# Altium schematic sheet CW562_DriverBoard_MotorDriver.SchDoc
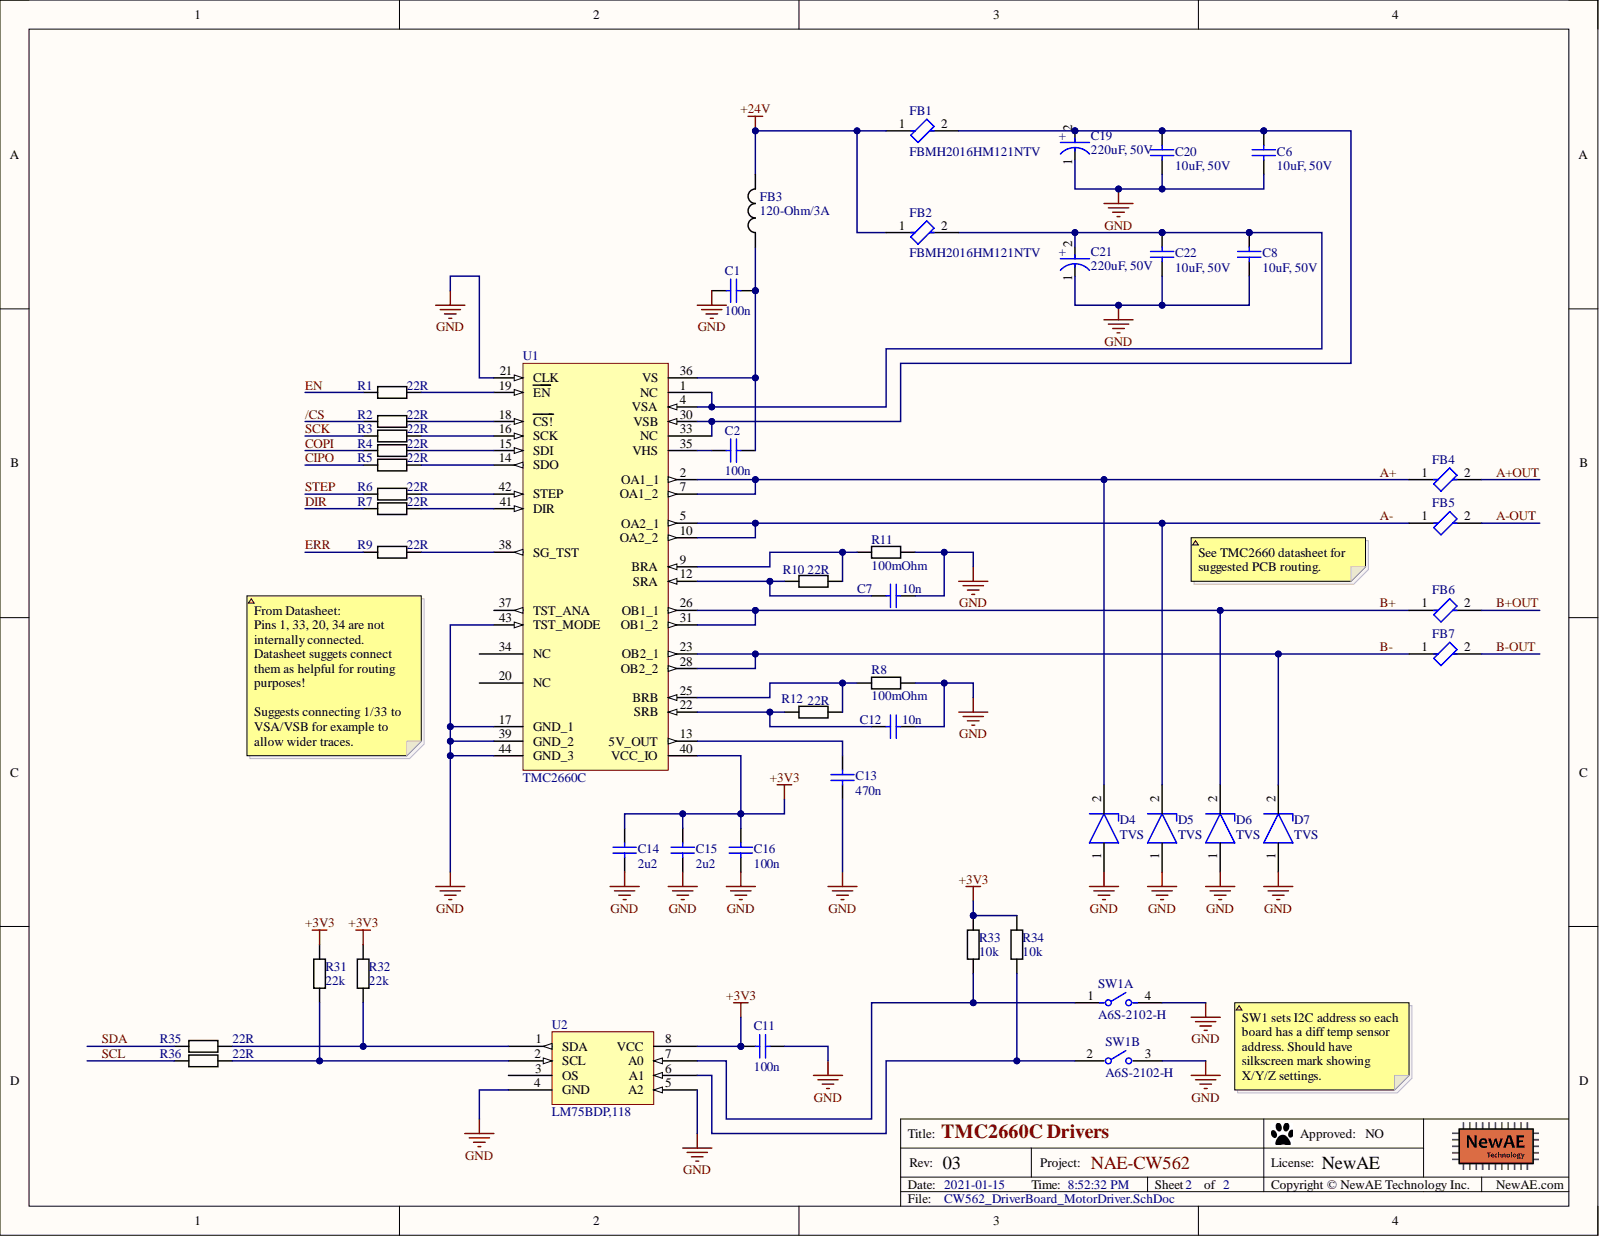
[diagram p0: CW562_DriverBoard_MotorDriver.SchDoc]

## Parts
C1  100n  [0603]
C2  100n  [0603]
C6  10uF, 50V  Voltage Rating DC: 50V  [MURA-1206_H_1.8_M]
C7  10n  [0603]
C8  10uF, 50V  Voltage Rating DC: 50V  [MURA-1206_H_1.8_M]
C11  100n  [0603]
C12  10n  [0603]
C13  470n  [0603]
C14  2u2  [0603]
C15  2u2  [0603]
C16  100n  [0603]
C19  220uF, 50V  [FP-FKG-MFG]
C20  10uF, 50V  Voltage Rating DC: 50V  [MURA-1206_H_1.8_M]
C21  220uF, 50V  [FP-FKG-MFG]
C22  10uF, 50V  Voltage Rating DC: 50V  [MURA-1206_H_1.8_M]
D4  TVS  [FP-S8-V-3915_01-001-MFG]
D5  TVS  [FP-S8-V-3915_01-001-MFG]
D6  TVS  [FP-S8-V-3915_01-001-MFG]
D7  TVS  [FP-S8-V-3915_01-001-MFG]
FB1  FBMH2016HM121NTV  [FP-FBMH2016-IPC_A]
FB2  FBMH2016HM121NTV  [FP-FBMH2016-IPC_A]
FB3  120-Ohm/3A  [0603]
FB4  FBMH2016HM121NTV  [FP-FBMH2016-IPC_A]
FB5  FBMH2016HM121NTV  [FP-FBMH2016-IPC_A]
FB6  FBMH2016HM121NTV  [FP-FBMH2016-IPC_A]
FB7  FBMH2016HM121NTV  [FP-FBMH2016-IPC_A]
R1  22R  [0603]
R2  22R  [0603]
R3  22R  [0603]
R4  22R  [0603]
R5  22R  [0603]
R6  22R  [0603]
R7  22R  [0603]
R8  100mOhm  [1206]
R9  22R  [0603]
R10  22R  [0603]
R11  100mOhm  [1206]
R12  22R  [0603]
R31  22k  [0603]
R32  22k  [0603]
R33  10k  [0603]
R34  10k  [0603]
R35  22R  [0603]
R36  22R  [0603]
SW1  A6S-2102-H  [FP-A6S-2102-H-MFG]  (parts A,B)
U1  TMC2660C  [TQFP44]
U2  LM75BDP,118  [TSSOP8]

## Nets
+3V3: C11.1 C14.1 C15.1 C16.1 R31.1 R32.1 R33.1 R34.1 U1.40(VCC_IO) U2.8(VCC)
+24V: FB1.1 FB2.1 FB3.2
GND: C1.1 C6.1 C7.1 C8.1 C11.2 C12.1 C13.2 C14.2 C15.2 C16.2 C19.1 C20.1 C21.1 C22.1 D4.1 D5.1 D6.1 D7.1 R8.2 R11.2 SW1.3 SW1.4 U1.17(GND_1) U1.21(CLK) U1.39(GND_2) U1.43(TST_MODE) U1.44(GND_3) U2.4(GND) U2.5(A2)
/CS: R2.1
A+: D4.2 FB4.1 U1.2(OA1_1) U1.7(OA1_2)
A+OUT: FB4.2
A-: D5.2 FB5.1 U1.5(OA2_1) U1.10(OA2_2)
A-OUT: FB5.2
B+: D6.2 FB6.1 U1.26(OB1_1) U1.31(OB1_2)
B+OUT: FB6.2
B-: D7.2 FB7.1 U1.23(OB2_1) U1.28(OB2_2)
B-OUT: FB7.2
CIPO: R5.1
COPI: R4.1
DIR: R7.1
EN: R1.1
ERR: R9.1
SCK: R3.1
SCL: R36.1
SDA: R35.1
STEP: R6.1
NetC1_2: C1.2 C2.2 FB3.1 U1.36(VS)
NetC2_1: C2.1 U1.35(VHS)
NetC6_2: C6.2 C19.2 C20.2 FB1.2 U1.30(VSB) U1.33(NC)
NetC7_2: C7.2 R10.1 U1.12(SRA)
NetC8_2: C8.2 C21.2 C22.2 FB2.2 U1.1(NC) U1.4(VSA)
NetC12_2: C12.2 R12.1 U1.22(SRB)
NetC13_1: C13.1 U1.13(5V_OUT)
NetR1_2: R1.2 U1.19(EN)
NetR2_2: R2.2 U1.18(CS!)
NetR3_2: R3.2 U1.16(SCK)
NetR4_2: R4.2 U1.15(SDI)
NetR5_2: R5.2 U1.14(SDO)
NetR6_2: R6.2 U1.42(STEP)
NetR7_2: R7.2 U1.41(DIR)
NetR8_1: R8.1 R12.2 U1.25(BRB)
NetR9_2: R9.2 U1.38(SG_TST)
NetR10_2: R10.2 R11.1 U1.9(BRA)
NetR31_2: R31.2 R36.2 U2.2(SCL)
NetR32_2: R32.2 R35.2 U2.1(SDA)
NetR33_2: R33.2 SW1.1 U2.7(A0)
NetR34_2: R34.2 SW1.2 U2.6(A1)

Not connected: U1 20(NC) 34(NC) 37(TST_ANA); U2 3(OS)

## Library data of the parts
C1 C2 C11 C16 = CCHIP-100n-0603: 100n, 0603; Manufacture 1: Kemet; Manufacture Part Number 1: C0603C104K5RACTU; Case-Metric: 1608; Component Group: Capacitor; Database Table Name: main; Rated Voltage: 50VDC; Case-EIA: 0603; Component Kind: Standard; Component Type: Standard; Library Name: NewAE_AltiumLib.DBLib; Mounting Technology: SMT; Part Number: CCHIP-100n-0603; Pin Count: 2; Supplier 1: Digi-Key; Supplier Part Number 1: 399-5089-2-ND; Value: 100n
C6 C8 C20 C22 = CMP-2000-05256-1: CAP, Ceramic, 10 uF, 10%, 50 V, 1206, RoHS, Tape and Reel; Manufacturer: Murata; Brand: MurataElectronics; Case Height: 1.6mm; Case Length: 3.2mm; Dielectric: X5R; Type: GeneralPurposeMLCC; Capacitance: 10uF; Case Code - in: 1206; Case Code - mm: 3216; Case Width: 1.6mm; Component Kind: Standard; Datasheet URL: http://download.siliconexpert.com/pdfs/2015/11/6/15/23/32/328/mrt_/manual/2905595417290350grm31cr61h106ka1223.pdf; Datasheet Version: Nov-15; Factory Pack Quantity: 2000; Library Name: Altium Content Vault; Manufacturer URL: http://www.murata.com/; Maximum Operating Temperature: +85C; Minimum Operating Temperature: -55C; Package / Case: 1206(3216metric); Packaging: Reel; Product: GeneralTypeMLCCs; Product Category: MultilayerCeramicCapacitorsMLCC-SMD/SMT; RoHS: Details; Series: GRM; Termination Style: SMD/SMT; Tolerance: 10%
C7 C12 = CCHIP-10n-0603: 10n, 0603; Case-Metric: 1608; Component Group: Capacitor; Database Table Name: main; Case-EIA: 0603; Component Kind: Standard; Component Type: Standard; Library Name: NewAE_AltiumLib.DBLib; Mounting Technology: SMT; Part Number: CCHIP-10n-0603; Pin Count: 2; Supplier 1: Digi-Key; Supplier Part Number 1: 399-1091-1-ND; Value: 10n
C13 = CCHIP-470n-0603-50V: 470n, 0603, 50V; Manufacture 1: Taiyo Yuden; Manufacture Part Number 1: UMK107B7474KA-TR; Component Group: Capacitor; Database Table Name: main; Rated Voltage: 50VDC; Case-EIA: 0603; Component Kind: Standard; Component Type: Standard; Library Name: NewAE_AltiumLib.DBLib; Mounting Technology: SMT; Part Number: CCHIP-470n-0603-50V; Pin Count: 2; RoHS: Yes; Supplier 1: Digi-Key; Supplier Part Number 1: 587-3170-1-ND; Temperature Range: -55C to 125C; Value: 470n
C14 C15 = CCHIP-2u2-0603: 2u2, 0603; Manufacture 1: Samsung; Manufacture Part Number 1: CL10B225KP8NNNC; Case-Metric: 1608; Component Group: Capacitor; Database Table Name: main; Rated Voltage: 10VDC; Case-EIA: 0603; Component Kind: Standard; Component Type: Standard; Library Name: NewAE_AltiumLib.DBLib; Mounting Technology: SMT; Part Number: CCHIP-2u2-0603; Pin Count: 2; Supplier 1: Digi-Key; Supplier Part Number 1: 1276-1134-2-ND; Value: 2u2
C19 C21 = CMP-05427-000014-1: CAP ALUM 220UF 20% 50V SMD; Manufacturer: Panasonic; Manufacturer Part Number: EEEFK1H221P; Automotive Grade: Grade 2; Dissipation Factor: 10%; Esr: 0.18Ω; Leakage Current: 110uA; Life: 2000Hours; Material: Aluminum; Ripple Current: 670mA; Automotive: Yes; Capacitance: 220uF; Category: Cap; Component Kind: Standard; ComponentLink2Description: Datasheet; ComponentLink2URL: https://industrial.panasonic.com/cdbs/www-data/pdf/RDE0000/ABA0000C1181.pdf; Device Class L1: Passive Components; Device Class L2: Capacitors; Device Class L3: Aluminum Electrolytic Capacitors; Digikey Description: CAP ALUM 220UF 20% 50V SMD; Height: 10.5mm; Lead Free: Yes; Library Name: Altium Content Vault; Mouser Description: Cap Aluminum Lytic 220uF 50V 20% (10 X 10.2mm) SMD 670mA 2000h 105C Automotive T/R; Octopart: https://octopart.com/eeefk1h221p-panasonic-1332836; Package: FKG; RoHS: TRUE; Temperature: -55°C to +105°C; Temperature Range High: +105°C; Temperature Range Low: -55°C; Tolerance: 20%; Value: 220uF; Voltage Rating: 50V
D4-D7 = CMP-02577-000004-1: TVS DIODE 30V 48.4V SMF; Manufacturer: Vishay; Manufacturer Part Number: SMF30A-E3-08; Breakdown Voltage: 33.3V; Capacitance: 207pF; Direction: Unidirectional; Max Junction Temp: +175°C; Number Of Channels: 1; Peak Pulse Current: 4.1A; Peak Pulse Power: 200W; Standoff Height: 0mm; Value: 207pF; Automotive: No; Category: Diode; Clamping Voltage: 48.4V; Component Kind: Standard; ComponentLink2Description: Datasheet; ComponentLink2URL: https://www.vishay.com/docs/85881/smf5v0atosmf58a.pdf; Device Class L1: Circuit Protection; Device Class L2: TVS Diodes; Device Class L3: unset; Digikey Description: TVS DIODE 30V 48.4V SMF; Height: 1.08mm; Lead Free: Yes; Library Name: Altium Content Vault; Mouser Description: ESD Suppressors / TVS Diodes 1000watt 30volt; Octopart: https://octopart.com/smf30a-e3-08-vishay-33599955; Package: S8-V-3915.01-001; Reverse Standoff Voltage: 30V; RoHS: TRUE; Temperature: -65°C to +175°C; Temperature Range High: +175°C; Temperature Range Low: -65°C
FB1 FB2 FB4-FB7 = CMP-14488-000003-1: FERRITE BEAD 120 OHM 0806 1LN; Manufacturer: Taiyo Yuden; Automotive Grade: Grade 1; Impedance At Frequency: 120Ω @ 100MHz; Rms Current: 4.5A; Series Resistance: 15mΩ; Test Frequency: 100MHz; Tolerance: 30%; Automotive: Yes; Category: FBead; Component Kind: Standard; ComponentLink2Description: Datasheet; ComponentLink2URL: https://drive.google.com/open?id=1UqnfixXgvx5IH7PdCJ4hyip2nSOHHYWH; Core Material: Ferrite; Device Class L1: Passive Components; Device Class L2: EMI / RFI Components; Device Class L3: Ferrite Beads and Chips; Digikey Description: FERRITE BEAD 120 OHM 0806 1LN; Height: 1.8mm; Ipc Land Pattern Name: CAPC200160X160; Lead Free: Yes; Library Name: Altium Content Vault; Manufacturer Part Number: FBMH2016HM121NTV; Mouser Description: Ferrite Beads HI CUR CHIP BD 0806 AEC-Q200 120 Ohm 30%; Octopart: https://octopart.com/fbmh2016hm121ntv-taiyo+yuden-46994035; Package: FBMH2016; RoHS: TRUE; Temperature: -40°C to +125°C; Temperature Range High: +125°C; Temperature Range Low: -40°C
FB3 = FBCHIP-120R-0603: 120 Ohm @ 100 MHz, 3A rated FB; Manufacture 1: Murata Electronics; Manufacture Part Number 1: BLM18SG121TN1D; Case-Metric: 1680; Component Group: Inductor; Database Table Name: main; PP Material Stack: 0; Value: 120R/3A; Case-EIA: 0603; Component Kind: Standard; Component Type: Standard; Library Name: NewAE_AltiumLib.DBLib; Part Number: FBCHIP-120R-0603; Pin Count: 2; PP Rotation: 0; Rated Power: 3A; Supplier 1: Digi-Key; Supplier Part Number 1: 490-3996-1-ND
R1-R7 R9 R10 R12 R35 R36 = RCHIP-22R-0603: 22R res, 0603, 1%, 1/10W; Manufacture 1: Yageo; Manufacture Part Number 1: RC0603FR-0722RL; Case-Metric: 1608; Component Group: Resistor; Database Table Name: main; Rated Power: 0.1W; Rated Voltage: 75VDC; Case-EIA: 0603; Component Kind: Standard; Component Type: Standard; Library Name: NewAE_AltiumLib.DBLib; Mounting Technology: SMT; Part Number: RCHIP-22R-0603; Pin Count: 2; Supplier 1: Digi-Key; Supplier Part Number 1: 311-22.0HRCT-ND; Temperature Range: -55C to 155C; Tolerance: 1%; Value: 22R
R8 R11 = RCHIP-0R100-1206: 0.100R res, 1206, 1/2W, 1%; Manufacture 1: Stackpole Electronics; Manufacture Part Number 1: CSR1206FTR100; Component Group: Resistor; Database Table Name: main; PP Material Stack: 0; Rated Power: 0.5W; Case-EIA: 1206; Component Kind: Standard; Component Type: Standard; Library Name: NewAE_AltiumLib.DBLib; Mounting Technology: SMT; Part Number: RCHIP-0R100-1206; Pin Count: 2; PP Rotation: 0; RoHS: Yes; Supplier 1: Digi-Key; Supplier Part Number 1: CSR1206FTR100CT-ND; Value: 100mOhm
R31 R32 = RCHIP-22K-0603: 22k res, 0603, 1%, 1/10W; Manufacture 1: Yageo; Manufacture Part Number 1: RC0603FR-0722KL; Case-Metric: 1608; Component Group: Resistor; Database Table Name: main; Rated Power: 0.1W; Rated Voltage: 75VDC; Case-EIA: 0603; Component Kind: Standard; Component Type: Standard; Library Name: NewAE_AltiumLib.DBLib; Mounting Technology: SMT; Part Number: RCHIP-22K-0603; Pin Count: 2; Supplier 1: Digi-Key; Supplier Part Number 1: 311-22.0KHRCT-ND; Temperature Range: -55C to 155C; Tolerance: 1%; Value: 22k
R33 R34 = RCHIP-10K-0603: 10k res, 0603, 1%, 1/10W; Manufacture 1: Yageo; Manufacture Part Number 1: RC0603FR-0710KL; Case-Metric: 1608; Component Group: Resistor; Database Table Name: main; Rated Power: 0.1W; Rated Voltage: 75VDC; Case-EIA: 0603; Component Kind: Standard; Component Type: Standard; Library Name: NewAE_AltiumLib.DBLib; Mounting Technology: SMT; Part Number: RCHIP-10K-0603; Pin Count: 2; Supplier 1: Digi-Key; Supplier Part Number 1: 311-10.0KHRCT-ND; Temperature Range: -55C to 155C; Tolerance: 1%; Value: 10k
SW1 = CMP-08827-000001-1: SWITCH SLIDE DIP SPST 25MA 24V; Manufacturer: Omron Electronics Inc-EMC Div; Contact Resistance: 200mΩ; Electromechanical Life: 1000Cycles; Number Of Circuits: 2; Standoff Height: 0.7mm; Ambient Temperature Range High: +70°C; Ambient Temperature Range Low: -20°C; Automotive: No; Category: Switch; Component Kind: Standard; ComponentLink2Description: Datasheet; ComponentLink2URL: https://omronfs.omron.com/en_US/ecb/products/pdf/en-a6s.pdf; Contact Current Rating: 25mA; Device Class L1: Electromechanical; Device Class L2: Switches; Device Class L3: DIP Switches; Digikey Description: SWITCH SLIDE DIP SPST 25MA 24V; Height: 3.8mm; Lead Free: Yes; Library Name: Altium Content Vault; Manufacturer Part Number: A6S-2102-H; Mount: Surface Mount; Mouser Description: DIP Switches / SIP Switches Flat with Seal Tape 2 Poles; Octopart: https://octopart.com/a6s-2102-h-omron-1417098; Package: 0; RoHS: TRUE; Temperature: -20°C to +70°C; Temperature Range High: +70°C; Temperature Range Low: -20°C; Throw Configuration: SPST; Voltage Rating Dc: 24V
U1 = TMC2660: Stepper Driver; Manufacture 1: TMC; Component Group: Analog IC; Database Table Name: main; PP Material Stack: 0; Component Kind: Standard; Component Type: Standard; Library Name: NewAE_AltiumLib.DBLib; Manufacture Part Number 1: TMC2660; Part Number: TMC2660; Pin Count: 44; PP Rotation: 0; Supplier 1: Digi-Key
U2 = LM75BDP,118: I2C temp sensor; Manufacture 1: NXP; Component Group: Analog IC; Database Table Name: main; Component Kind: Standard; Component Type: Standard; Library Name: NewAE_AltiumLib.DBLib; Manufacture Part Number 1: LM75BDP,118; Mounting Technology: SMT; Part Number: LM75BDP,118; Pin Count: 8; RoHS: Yes; Supplier 1: Digi-Key; Supplier Part Number 1: 568-4768-1-ND
